# Altium schematic sheet Pressure Sensor Breakout Rev 2.SchDoc
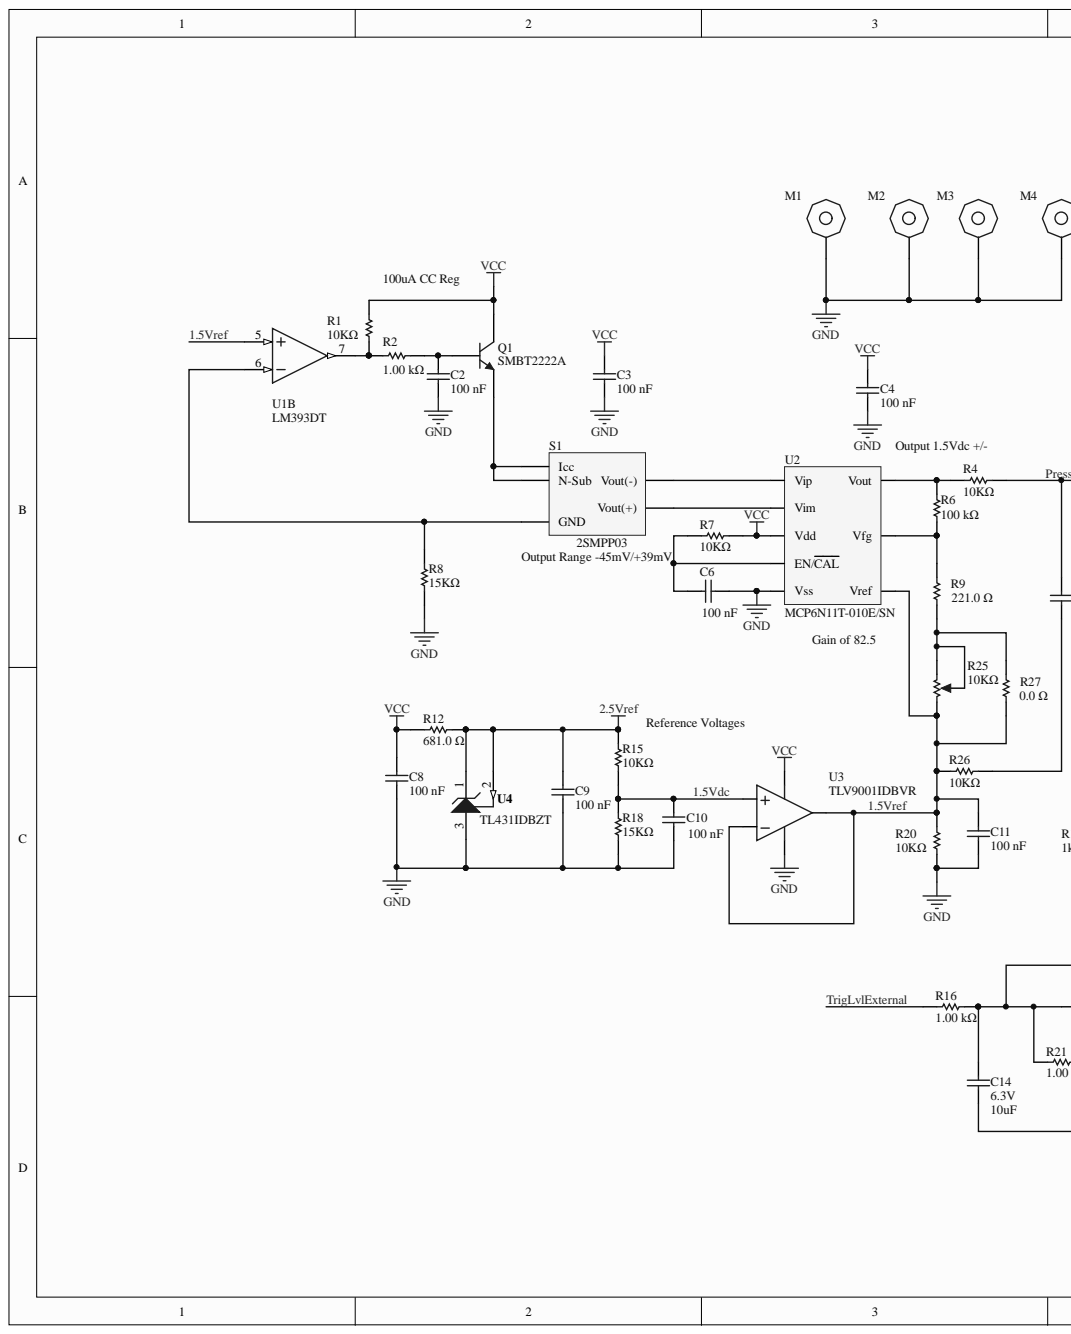
[diagram p0: Pressure Sensor Breakout Rev 2.SchDoc, left]
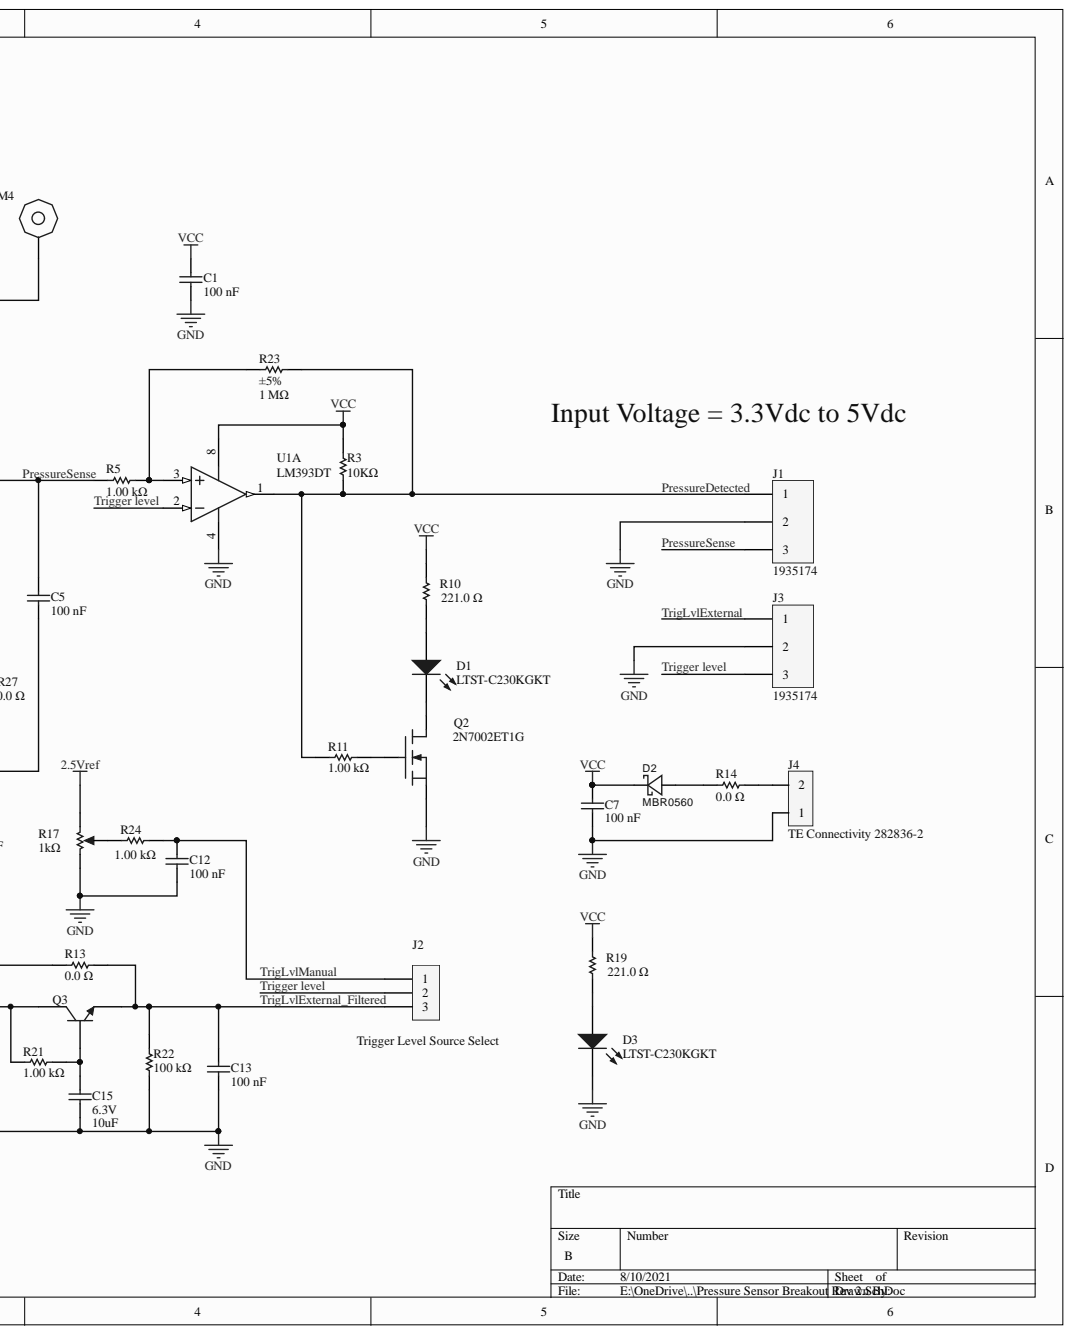
[diagram p1: Pressure Sensor Breakout Rev 2.SchDoc, right]

## Parts
C1  Capacitance: 100 nF  [Cap,0805]  (hidden comment: 08055C104JAT2A)
C2  08055C104JAT2A  [Cap,0805]  (value not drawn)
C3  Capacitance: 100 nF  [Cap,0805]  (hidden comment: 08055C104JAT2A)
C4  Capacitance: 100 nF  [Cap,0805]  (hidden comment: 08055C104JAT2A)
C5  Capacitance: 100 nF  [Cap,0805]  (hidden comment: 08055C104JAT2A)
C6  Capacitance: 100 nF  [Cap,0805]  (hidden comment: 08055C104JAT2A)
C7  Capacitance: 100 nF  [Cap,0805]  (hidden comment: 08055C104JAT2A)
C8  08055C104JAT2A  [Cap,0805]  (value not drawn)
C9  08055C104JAT2A  [Cap,0805]  (value not drawn)
C10  Capacitance: 100 nF  [Cap,0805]  (hidden comment: 08055C104JAT2A)
C11  Capacitance: 100 nF  [Cap,0805]  (hidden comment: 08055C104JAT2A)
C12  Capacitance: 100 nF  [Cap,0805]  (hidden comment: 08055C104JAT2A)
C13  Capacitance: 100 nF  [Cap,0805]  (hidden comment: 08055C104JAT2A)
C14  Capacitance: 10uF  Voltage - Rated: 6.3V  [Cap,0805]  (hidden comment: Cap)
C15  Capacitance: 10uF  Voltage - Rated: 6.3V  [Cap,0805]  (hidden comment: Cap)
D1  LTST-C230KGKT  [LED,SMD,Bottom Entry,1206]
D2  MBR0560  [SOD123]
D3  LTST-C230KGKT  [LED,SMD,Bottom Entry,1206]
J1  1935174  [CONNECTOR,TerminalBlock,3 POS,1935174]
J2  Generic Header  [Header,3POS,0.1",Vert]  (value not drawn)
J3  1935174  [CONNECTOR,TerminalBlock,3 POS,1935174]
J4  TE Connectivity 282836-2  [TERM BLOCK 2POS SIDE ENTRY 5MM]
M1  M3 hex Standoff  [Standoff,Hex,M3,8mm]  (value not drawn)
M2  M3 hex Standoff  [Standoff,Hex,M3,8mm]  (value not drawn)
M3  M3 hex Standoff  [Standoff,Hex,M3,8mm]  (value not drawn)
M4  M3 hex Standoff  [Standoff,Hex,M3,8mm]  (value not drawn)
Q1  SMBT2222A  [SOT23-3]
Q2  2N7002ET1G  [SOT23-3]
Q3  NPN  [SOT23-3]  (value not drawn)
R1  Resistance: 10KΩ  [res, 0805, HD]  (hidden comment: Vishay / Dale CRCW080510K0FKTA)
R2  Vishay CRCW08051K00JNEA  [res, 0805, HD]  (value not drawn)
R3  Resistance: 10KΩ  [res, 0805, HD]  (hidden comment: Vishay / Dale CRCW080510K0FKTA)
R4  Resistance: 10KΩ  [res, 0805, HD]  (hidden comment: Vishay / Dale CRCW080510K0FKTA)
R5  Resistance: 1.00 kΩ  [res, 0805, HD]  (hidden comment: Vishay CRCW08051K00JNEA)
R6  Resistance: 100 kΩ  [res, 0805, HD]  (hidden comment: CRCW0805100KFKEA)
R7  Resistance: 10KΩ  [res, 0805, HD]  (hidden comment: Vishay / Dale CRCW080510K0FKTA)
R8  Resistance: 15KΩ  [res, 0805, HD]  (hidden comment: CRGCQ0805F15K)
R9  Vishay Dale CRCW0805221RFKTA  [res, 0805, HD]  (value not drawn)
R10  Vishay Dale CRCW0805221RFKTA  [res, 0805, HD]  (value not drawn)
R11  Resistance: 1.00 kΩ  [res, 0805, HD]  (hidden comment: Vishay CRCW08051K00JNEA)
R12  Resistance: 681.0 Ω  [res, 0805, HD]  (hidden comment: CRCW0805681RFKEA)
R13  Resistance: 0.0 Ω  [res, 0805, HD]  (hidden comment: Res1)
R14  Resistance: 0.0 Ω  [res, 0805, HD]  (hidden comment: CR0805-J/-000ELF)
R15  Resistance: 10KΩ  [res, 0805, HD]  (hidden comment: Vishay / Dale CRCW080510K0FKTA)
R16  Resistance: 1.00 kΩ  [res, 0805, HD]  (hidden comment: Vishay CRCW08051K00JNEA)
R17  Resistance: 1kΩ  [Res,SMD,POT,TC33 Series]  (hidden comment: TC33X-2-102E)  (mechanical)
R18  Resistance: 15KΩ  [res, 0805, HD]  (hidden comment: CRGCQ0805F15K)
R19  Vishay Dale CRCW0805221RFKTA  [res, 0805, HD]  (value not drawn)
R20  Resistance: 10KΩ  [res, 0805, HD]  (hidden comment: Vishay / Dale CRCW080510K0FKTA)
R21  Resistance: 1.00 kΩ  [res, 0805, HD]  (hidden comment: Vishay CRCW08051K00JNEA)
R22  Resistance: 100 kΩ  [res, 0805, HD]  (hidden comment: Res,0805,100kΩ,1%,100ppm,CRCW0805100KFKEA)
R23  Resistance: 1 MΩ  Tolerance: ±5%  [res, 0805, HD]  (hidden comment: CR0805-JW-105ELF)
R24  Resistance: 1.00 kΩ  [res, 0805, HD]  (hidden comment: Vishay CRCW08051K00JNEA)
R25  Resistance: 10KΩ  [Res,TH,POT,TopAdj]  (hidden comment: CT94EW103)
R26  Resistance: 10KΩ  [res, 0805, HD]  (hidden comment: Vishay / Dale CRCW080510K0FKTA)
R27  Resistance: 0.0 Ω  [res, 0805, HD]  (hidden comment: CR0805-J/-000ELF)
S1  2SMPP03  [2SMPP03,6-SMD Module]
U1  LM393DT  [SOIC-8]  (parts A,B)
U2  MCP6N11T-010E/SN  [SOIC-8]
U3  TLV9001IDBVR  [SOT23-5]
U4  Manufacturer Part Number: TL431IDBZT  [SOT23-3]  (hidden comment: Shunt Regulator,2.5Vdc TL431IDBZT)

## Nets
2.5VREF: C9.1 R12.1 R15.2 R17.3 U4.1 U4.2
GND: C1.2 C2.2 C3.2 C4.2 C6.1 C7.2 C8.2 C9.2 C10.2 C11.2 C12.2 C13.2 C14.1 C15.1 D3.1 J1.2 J3.2 J4.1 M1.0 M2.0 M3.0 M4.0 Q2.2 R8.1 R17.1 R18.1 R20.1 R22.1 U1.4 U2.4(Vss) U3.2 U4.3
VCC: C1.1 C3.1 C4.1 C7.1 C8.1 D2.1 Q1.3 R1.2 R3.2 R7.2 R10.2 R12.2 R19.2 U1.8 U2.7(Vdd) U3.5
1.5VDC: C10.1 R15.1 R18.2 U3.3
1.5VREF: C11.1 R20.2 R25.1 R26.2 R27.1 U1.5 U2.5(Vref) U3.1 U3.4
PRESSUREDETECTED: J1.1 R3.1 R11.2 R23.1 U1.1
PRESSURESENSE: C5.1 J1.3 R4.1 R5.1
TRIGGER LEVEL: J2.2 J3.3 U1.2
TRIGLVLEXTERNAL: J3.1 R16.1
TRIGLVLEXTERNAL_FILTERED: C13.1 J2.3 Q3.3 R13.1 R22.2
TRIGLVLMANUAL: C12.1 J2.1 R24.1
NetC2_1: C2.1 Q1.1 R2.1
NetC5_2: C5.2 R26.1
NetC6_2: C6.2 R7.1 U2.8(EN/CAL)
NetC14_2: C14.2 Q3.1 R13.2 R16.2 R21.2
NetC15_2: C15.2 Q3.2 R21.1
NetD1_1: D1.1 Q2.3
NetD1_2: D1.2 R10.1
NetD2_2: D2.2 R14.2
NetD3_2: D3.2 R19.1
NetJ4_2: J4.2 R14.1
NetQ1_2: Q1.2 S1.5(N-Sub) S1.6(Icc)
NetQ2_1: Q2.1 R11.1
NetR1_1: R1.1 R2.2 U1.7
NetR4_2: R4.2 R6.2 U2.6(Vout)
NetR5_2: R5.2 R23.2 U1.3
NetR6_1: R6.1 R9.2 U2.1(Vfg)
NetR8_2: R8.2 S1.3(GND) U1.6
NetR9_1: R9.1 R25.2 R25.3 R27.2
NetR17_2: R17.2 R24.2
NetS1_1: S1.1(Vout(+)) U2.2(Vim)
NetS1_4: S1.4(Vout(-)) U2.3(Vip)

## Library data of the parts
C1 C3-C7 C10-C13 = Cap,0805,100nf,50V,5%,08055C104JAT2A: Capacitor; Ceramic; Cap 0.1 uF; Tol 5%; Vol-Rtg 50 VDC; SMT; 0805; X7R; Tape & Reel; Case/Package (SI): 2012; Detailed Description: 0.1µF ±5% 50V Ceramic Capacitor X7R 0805 (2012 Metric); Insulation Resistance: 100 GΩ; Lead-Free Status: Lead Free; Voltage Rating (DC): 50.0 V; Capacitance Tolerance: ±5%; Case/Package: 0805; Component Kind: Standard; Dielectric Characteristic: X7R; digikey url: http://www.digikey.ca/product-detail/en/avx-corporation/08055C104JAT2A/478-3352-1-ND/930144; Library Name: Master JULY2019.SchLib; Manufacturer Part Number: 08055C104JAT2A; Mounting Style: Surface Mount; Number of Pins: 2; Octopart URL: http://octopart.com/08055c104jat2a-avx-55388010; Operating Temperature: -55°C ~ 125°C; Packaging: Tape & Reel (TR), Cut Tape (CT); REACH SVHC Compliance: No SVHC; RoHS: Compliant; Size-Height: 710 µm; Size-Length: 2.01 mm; Size-Thickness: 940 µm; Size-Width: 1.24 mm; Supplier 1: Digi-Key; Supplier Part Number 1: 478-3352-1-ND
C2 C8 C9 = Cap,0805,100nf,50V,5%,08055C104JAT2A: Capacitor; Ceramic; Cap 0.1 uF; Tol 5%; Vol-Rtg 50 VDC; SMT; 0805; X7R; Tape & Reel; Capacitance: 100 nF; Case/Package (SI): 2012; Detailed Description: 0.1µF ±5% 50V Ceramic Capacitor X7R 0805 (2012 Metric); Insulation Resistance: 100 GΩ; Lead-Free Status: Lead Free; Voltage Rating (DC): 50.0 V; Capacitance Tolerance: ±5%; Case/Package: 0805; Component Kind: Standard; Dielectric Characteristic: X7R; digikey url: http://www.digikey.ca/product-detail/en/avx-corporation/08055C104JAT2A/478-3352-1-ND/930144; Library Name: Master JULY2019.SchLib; Manufacturer Part Number: 08055C104JAT2A; Mounting Style: Surface Mount; Number of Pins: 2; Octopart URL: http://octopart.com/08055c104jat2a-avx-55388010; Operating Temperature: -55°C ~ 125°C; Packaging: Tape & Reel (TR), Cut Tape (CT); REACH SVHC Compliance: No SVHC; RoHS: Compliant; Size-Height: 710 µm; Size-Length: 2.01 mm; Size-Thickness: 940 µm; Size-Width: 1.24 mm; Supplier 1: Digi-Key; Supplier Part Number 1: 478-3352-1-ND
C14 C15 = Cap,0805,10uf,6.3V,10%,CL21A106KQFNNNG: CL21 Series 10 uF 6.3V ±10 % Tolerance X5R SMT Multilayer Ceramic Capacitor; Lead-Free Status: Lead Free; Other Names: 1276-6457-2; Tolerance: ±10%; Applications: General Purpose; Component Kind: Standard; mfg P/N: CL21A106KQFNNNG; Mounting Style: Surface Mount; Operating Temperature: -55°C ~ 85°C; Package / Case: 0805 (2012 Metric); RoHS: Compliant; Library Name: Master JUL_2019-Shawn.IntLib
D1 D3 = LED,1206,Green,SMD,BtmEnt,LTST-C230KGKT: LED GREEN CLEAR CHIP SMD; Manufacturer: Lite-On Inc.; Categories: Optoelectronics   LED Indication - Discrete; Configuration: Standard; Current - Test: 20mA; Detailed Description: Green 571nm LED Indication - Discrete 2V 1206 (3216 Metric); Lens Color: Colorless; Lens Size: 1.50mm x 1.60mm; Lens Style: Rectangle with Flat Top; Millicandela Rating: 35mcd; Viewing Angle: 130°; Voltage - Forward (Vf) (Typ): 2V; Wavelength - Dominant: 571nm; Wavelength - Peak: 574nm; Color: Green; Component Kind: Standard; Height (Max): 1.10mm; Lens Transparency: Clear; mfg P/N: LTST-C230KGKT; Mounting Type: Surface Mount, Bottom Entry; Package / Case: 1206 (3216 Metric); Part Status: Active; Size / Dimension: 3.20mm L x 1.60mm W; Supplier 1: Digi-Key; Supplier Device Package: Chip LED; Supplier Part Number 1: 160-1456-1-ND; Library Name: Master DEC 2020.SchLib
D2 = Diode,SOD123,Shottky,60V,0.5A,MBR0560: Dio,Sig,Schtky,0.5A,60V,SOD123; Manufacturer: MCC; Details: 700mV @ 500mA, 200µA @ 60V,30pF @ 4V, 1MHz; mfg P/N: MBR0560-TP; Not Stuffed: N/S; Component Kind: Standard; Current: 0.5A; Fast Supplier: Digi-Key; Package: SOD123; Supplier 1: Digi-Key; Supplier 2: LCSC; Supplier Part Number 1: MBR0560TPMSCT-ND; Supplier Part Number 2: C150515; Voltage: 60V; Library Name: Master DEC 2020.SchLib
J1 J3 = Conn,TH,WireTerminal,3Pos,18A,300Vdc,1935174: TERM BLK 3POS SIDE ENTRY 5MM PCB; Manufacturer: Phoenix Contact; Current: 18A; Detailed Description: 3 Position Wire to Board Terminal Block Horizontal with Board 0.197" (5.00mm) Through Hole; Mating Orientation: Horizontal with Board; Number of Levels: 1; Other Names: 277-1578, PT 1.5/3-5.0-H, PT1.5/3-5.0-H; Pitch: 0.197"" (5.00mm); Positions Per Level: 3; Screw Thread: M2.6; Torque - Screw: 0.35-0.4 Nm (3.1-3.5 Lb-In); Voltage: 300V; Wire Gauge: 12-26 AWG; Wire Termination: Screw; Category: Connectors, Interconnects  Terminal Blocks - Wire to Board; Color: Green; Component Kind: Standard; Features: Interlocking (Side); Housing Material: Polyamide (PA), Nylon; mfg P/N: 1935174; Mounting Type: Through Hole; Package: Bulk; Part Status: Active; Series: COMBICON PT; Supplier 1: Digi-Key; Supplier Part Number 1: 277-1578-ND; Library Name: Master DEC 2020.SchLib
J2 = Header 1x3,0.1",TH,Generic: Generic Header 3 pos header 0.1"; Component Kind: Standard; Library Name: Master JULY2019.SchLib
J4 = TERM BLOCK 2POS SIDE ENTRY 5MM, 282836-2: Wire to Board 2 Position 5 mm Pitch 30-16 AWG Euro Style Terminal Block; Current Rating: 13.5 A; ELV Compliant: Compliant; Lead-Free Status: Lead Free; Number of Contacts: 2; Pin Pitch: 0.005 m; Voltage Rating (AC): 250.0 V; Wire Gauge: 15.0 AWG; Color: Green; Component Kind: Standard; Contact Material: Brass; Contact Plating: Tin; Flammability Rating: UL 94 V-0; Housing Color: Green; Housing Material: Nylon 6/6; Insulation Material: Nylon; Lifecycle Status: Active; Manufacturer Part Number: 282836-2; Mounting Style: PCB; Number of Positions: 2; Orientation: Right Angle; REACH SVHC Compliance: No SVHC; RoHS: Compliant; Supplier 1: TME; Supplier 2: Newark; Supplier 3: Digi-Key; Supplier 4: Digi-Key; Supplier Part Number 4: A98076-ND; Library Name: Master DEC 2020.SchLib
M1-M4 = Mech,Standoff, M3, Hex: HEX STANDOFF M3,generic; Component Kind: Standard; Library Name: Master JULY2019.SchLib; Supplier 1: Digi-Key; Supplier Part Number 1: 36-24314-ND
Q1 Q3 = Trans,40V,600mA,0.33W, SMBT2222A: NPN Silicon Switching Transistor, 40 V VCEO, 600 mA IC, SOT23, Reel, Green; Current - Collector Cutoff (Max): 10nA (ICBO); DC Current Gain (hFE) (Min) @ Ic, Vce: 100 @ 150mA, 10V; Frequency - Transition: 300MHz; Power Dissipation: 0.33 W; Vce Saturation (Max) @ Ib, Ic: 1V @ 50mA, 500mA; Voltage - Collector Emitter Breakdown (Max): 40V; Component Kind: Standard; mfg P/N: SMBT2222A; Mounting Style: Surface Mount; Mounting Type: Surface Mount; Number of Pins: 3; Package / Case: TO-236-3, SC-59, SOT-23-3; Packaging: Cut Tape (CT); Polarity: NPN; REACH SVHC Compliance: No SVHC; RoHS: Compliant; Supplier Device Package: SOT-23-3
  Q1: Library Name: Master JULY2019.SchLib; Supplier 1: Digi-Key; Supplier 2: Digi-Key; Supplier 3: Digi-Key; Supplier 4: LCSC; Supplier Part Number 1: SMBT2222AE6327HTSA1CT-ND; Supplier Part Number 2: MMBT2222ALT1HTSA1CT-ND; Supplier Part Number 3: SMBT2222AE6327HTSA1DKR-ND; Supplier Part Number 4: C151510
  Q3: Library Name: Master JUL_2019-Shawn.IntLib
Q2 = MOSFET,N-Channel,SOT23,0.25A,60V,2N7002ET1G: Small Signal MOSFET, 60 V, 310 mA, Single, N-Channel, 3-Pin SOT-23, Pb-Free, Tape and Reel; Manufacturer: ON Semiconductor; Continuous Drain Current (Ids): 0.25A; Drain to Source Voltage (Vds): 60.0 V; Lead-Free Status: Lead Free; Power Dissipation (Max): 350mW (Ta); Case/Package: SOT-23-3; Component Kind: Standard; Halogen Free Status: Halogen Free; Lifecycle Status: Active; Manufacturer Part Number: 2N7002ET1G; Number of Pins: 3; Polarity: N-Channel; REACH SVHC Compliance: No SVHC; RoHS: Compliant; Supplier 1: Digi-Key; Supplier 2: LCSC; Supplier Part Number 1: 2N7002ET1GOSCT-ND; Supplier Part Number 2: C71533; Library Name: Master DEC 2020.SchLib
R1 R3 R4 R7 R15 R20 R26 = Res,0805,10.0kΩ,1%,100 ppm,CRCW080510K0FKTA: RES SMD 10K OHM 1% 1/8W 0805; Case/Package (SI): 2012; Lead-Free Status: Contains Lead; Power Dissipation: 0.125 W; Temperature Coefficient: 100.0 ppm/C; Voltage Rating (DC): 150.0 V; Case/Package: 0805; Component Kind: Standard; Mounting Style: Surface Mount; Number of Pins: 2; Power Rating: 0.125 W; REACH SVHC Compliance: No SVHC; Resistance Tolerance: 1%; RoHS: Non-Compliant; Size-Height: 0.00045 m; Size-Length: 0.002 m; Size-Width: 0.00125 m; Supplier 1: Digi-Key; Supplier Part Number 1: 541-3016-1-ND
  R1 R3 R7 R15 R20: Library Name: Master JULY2019.SchLib
  R4 R26: Library Name: Master DEC 2020.SchLib
R2 = Res,0805,1.00 kΩ,5%,200ppm,CRCW08051K00JNEA: Res Thick Film 0805 1K Ohm 5% 0.125W(1/8W) ±200ppm/C Molded SMD Automotive Paper T/R; Case/Package (SI): 2012; Lead-Free Status: Lead Free; Power Rating: 125 mW; Resistance: 1.00 kΩ; Temperature Coefficient: 200 ppm/°C; Voltage Rating (DC): 150 V; Case/Package: 0805; Component Kind: Standard; Library Name: Master JULY2019.SchLib; Manufacturer Part Number: CRCW08051K00JNEA; Mounting Style: Surface Mount; Number of Pins: 2; Packaging: Tape & Reel (TR), Cut Tape (CT); REACH SVHC Compliance: No SVHC; Resistance Tolerance: ±5%; RoHS: Compliant; Size-Height: 450 µm; Size-Length: 2.00 mm; Size-Width: 1.25 mm; Supplier 1: Digi-Key; Supplier 2: Digi-Key; Supplier Part Number 1: 541-1.0KADKR-ND; Supplier Part Number 2: 541-1.0KACT-ND
R5 R11 R16 R21 R24 = Res,0805,1.00 kΩ,5%,200ppm,CRCW08051K00JNEA: Res Thick Film 0805 1K Ohm 5% 0.125W(1/8W) ±200ppm/C Molded SMD Automotive Paper T/R; Case/Package (SI): 2012; Lead-Free Status: Lead Free; Power Rating: 125 mW; Temperature Coefficient: 200 ppm/°C; Voltage Rating (DC): 150 V; Case/Package: 0805; Component Kind: Standard; Library Name: Master JULY2019.SchLib; Manufacturer Part Number: CRCW08051K00JNEA; Mounting Style: Surface Mount; Number of Pins: 2; Packaging: Tape & Reel (TR), Cut Tape (CT); REACH SVHC Compliance: No SVHC; Resistance Tolerance: ±5%; RoHS: Compliant; Size-Height: 450 µm; Size-Length: 2.00 mm; Size-Width: 1.25 mm
  R5 R11 R24: Supplier 1: Digi-Key; Supplier 2: Digi-Key; Supplier Part Number 1: 541-1.0KADKR-ND; Supplier Part Number 2: 541-1.0KACT-ND
R6 R22 = Res,0805,100kΩ,1%,100ppm,CRCW0805100KFKEA: 100 kOhms ±1% 0.125W, 1/8W Chip Resistor 0805 (2012 Metric) Thick Film; Manufacturer: Vishay; Case/Package (SI): 2012; Lead-Free Status: Lead Free; Power Rating: 125 mW; Temperature Coefficient: -100 ppm/°C to 100 ppm/°C; Case/Package: 0805; Component Kind: Standard; Manufacturer Part Number: CRCW0805100KFKEA; Number of Pins: 2; Operating Temperature: 150 °C (max); Packaging: Tape & Reel (TR), Reel, Cut Tape (CT); Resistance Tolerance: ±1%; RoHS: Compliant; Size-Height: 450 µm; Size-Length: 2.00 mm; Size-Width: 1.25 mm; Supplier 1: RS; Supplier 1 Part Number: 679-0803
  R6: Supplier 2: Digi-Key; Supplier Part Number 2: 541-100KCCT-ND; Library Name: Master DEC 2020.SchLib
  R22: Library Name: Master JULY2019.SchLib
R8 R18 = Res,0805,15KΩ,1%,±100ppm/°C,CRGCQ0805F15K: 15 kOhms ±1% 0.125W, 1/8W Chip Resistor 0805 (2012 Metric) Automotive AEC-Q200, Moisture Resistant Thick Film; Component Kind: Standard; Composition: Thick Film; Features: Automotive AEC-Q200, Moisture Resistant; Library Name: Master JULY2019.SchLib; mfg P/N: CRGCQ0805F15K; Operating Temperature: -55°C ~ 155°C; Package / Case: 0805 (2012 Metric); Power (Watts): 0.125W, 1/8W; Supplier 1: Digi-Key; Supplier Device Package: 0805; Supplier Part Number 1: A129763CT-ND; Temperature Coefficient: ±100ppm/°C; Tolerance: ±1%
R9 R10 R19 = Res,0805,221Ω,1%,100ppm,CRCW0805221RFKTA: RES SMD 221 OHM 1% 1/8W 0805; Case/Package (SI): 2012; Lead-Free Status: Contains Lead; Power Dissipation: 0.125 W; Resistance: 221.0 Ω; Temperature Coefficient: 100.0 ppm/°C; Voltage Rating (DC): 150.0 V; Case/Package: 0805; Component Kind: Standard; Mounting Style: Surface Mount; Number of Pins: 2; Power Rating: 0.125 W; Resistance Tolerance: ±1%; RoHS: Non-Compliant; Size-Height: 0.00045 m; Size-Length: 0.002 m; Size-Width: 0.00125 m; Supplier 1: Digi-Key; Supplier Part Number 1: 541-221CCT-ND; Library Name: Master DEC 2020.SchLib
R12 = Res,0805,681.0 Ω,±1%,100.0 ppm/°C,150.0 V,CRCW0805681RFKEA: RES SMD 681 OHM 1% 1/8W 0805; Case/Package (SI): 2012; Lead-Free Status: Lead Free; Power Rating: 0.125 W; Voltage Rating (DC): 150.0 V; Case/Package: 0805; Component Kind: Standard; Library Name: Master JULY2019.SchLib; mfg P/N: CRCW0805681RFKEA; Mounting Style: Surface Mount; Number of Pins: 2; Octopart Url: https://octopart.com/crcw0805681rfkea-vishay-39820193; REACH SVHC Compliance: No SVHC; Resistance Tolerance: ±1%; RoHS: Compliant; Size-Height: 0.00045 m; Size-Length: 0.002 m; Size-Width: 0.00125 m; Supplier 1: Digi-Key; Supplier Part Number 1: 541-681CCT-ND; Temperature Coefficient: 100.0 ppm/°C
R13 = Res,0805,0.0Ω,150V: RES SMD 0.0 OHM JUMPER 1/3W 0805; Lead-Free Status: Lead Free; Power Rating: 0.125 W; Voltage Rating (DC): 150.0 V; Case/Package: 0805; Component Kind: Standard; Library Name: Master JULY2019.SchLib; Number of Pins: 2; Octopart Url: https://octopart.com/crcw08050000z0eahp-vishay-44321084; RoHS: Compliant
R14 R27 = Res,0805,0.0Ω,150V, CR0805-J/-000ELF: RES SMD 0 OHM JUMPER 1/8W 0805; Categories: Resistors   Chip Resistor - Surface Mount; Detailed Description: 0 Ohms Jumper 0.125W, 1/8W Chip Resistor 0805 (2012 Metric) Moisture Resistant Thick Film; Lead-Free Status: Lead Free; Power Rating: 0.125 W; Voltage Rating (DC): 150.0 V; Base Part Number: CR0805; Case/Package: 0805; Component Kind: Standard; Composition: Thick Film; Number of Pins: 2; Operating Temperature: -55°C ~ 155°C; RoHS: Compliant; Supplier 1: Digi-Key; Supplier 2: Digi-Key; Supplier Part Number 1: CR0805-J/-000ELFCT-ND; Supplier Part Number 2: CR0805-J/-000ELFDKR-ND; Tolerance: Jumper; Library Name: Master DEC 2020.SchLib
R17 = Res,POT,SMD,1kΩ,0.1W, TC33X-2-102E: TRIMMER 1k OHM 0.1W J LEAD TOP; Manufacturer: Bourns Inc.; Adjustment Type: Top Adjustment; Detailed Description: 1k Ohms 0.1W, 1/10W J Lead Surface Mount Trimmer Potentiometer Cermet 1 Turn Top Adjustment; Number of Turns: 1; Power (Watts): 0.1W, 1/10W; Resistive Material: Cermet; Temperature Coefficient: ±250ppm/°C; Tolerance: ±25%; Category: Potentiometers, Variable Resistors  Trimmer Potentiometers; Datasheets: TC33 Series; Library Name: Master JULY2019.SchLib; mfg P/N: TC33X-2-102E; Mounting Type: Surface Mount; Part Status: Active; Series: Trimpot® TC33 - Open Frame; Size / Dimension: Rectangular - 0.150"" x 0.142"" Face x 0.047"" H (3.80mm x 3.60mm x 1.20mm); Supplier 1: Digi-Key; Supplier 2: Digi-Key; Supplier 3: Digi-Key; Supplier Part Number 3: TC33X-102ECT-ND; Termination Style: J Lead
R23 = Res,0805,1MegΩ,5%,200ppm,0805W8F1005T5E: RES SMD 1M OHM 5% 1/8W 0805; Manufacturer: Bourns Inc.; Detailed Description: 1 MOhms ±5% 0.125W, 1/8W Chip Resistor 0805 (2012 Metric) Moisture Resistant Thick Film; Power (Watts): 0.125W, 1/8W; Temperature Coefficient: ±200ppm/°C; Category: Resistors  Chip Resistor - Surface Mount; Component Kind: Standard; Composition: Thick Film; Features: Moisture Resistant; Height - Seated (Max): 0.024"" (0.60mm); JLC ALt LCSC Part #: C26108; Library Name: Master JULY2019.SchLib; mfg P/N: CR0805-JW-105ELF; Operating Temperature: -55°C ~ 155°C; Package / Case: 0805 (2012 Metric); Part Status: Active; Series: CR0805; Size / Dimension: 0.079"" L x 0.049"" W (2.00mm x 1.25mm); Supplier 1: Digi-Key; Supplier Device Package: 0805; Supplier Part Number 1: CR0805-JW-105ELFCT-ND
R25 = Res,POT,TH,10KΩ,0.5W,±10%,±100ppm/°C,CT94EW103: TRIMMER 10K OHM 0.5W PC PIN TOP; Manufacturer: Nidec Copal Electronics; Adjustment Type: Top Adjustment; Detailed Description: 10 kOhms 0.5W, 1/2W PC Pins Through Hole Trimmer Potentiometer Cermet 18 Turn Top Adjustment; Number of Turns: 18; Power (Watts): 0.5W, 1/2W; Resistive Material: Cermet; Tolerance: ±10%; Category: Potentiometers, Variable Resistors; Component Kind: Standard; Library Name: Master DEC 2020.SchLib; mfg P/N: CT94EW103; Mounting Type: Through Hole; Part Status: Active; Series: CT94; Size / Dimension: Square - 0.378"" L x 0.189"" W x 0.378"" H (9.60mm x 4.80mm x 9.60mm); Supplier 1: Digi-Key; Supplier Part Number 1: CT94EW103-ND; Temperature Coefficient: ±100ppm/°C; Termination Style: PC Pins
S1 = Sensor,PRESSURE,Air, ±7.25PSI, 2SMPP03: SENSOR PRESSURE -50-50 KPA PCB; Manufacturer: Omron Electronics Inc-EMC Div; Accuracy: ±0.5%; Detailed Description: Pressure Sensor ±7.25PSI (±50kPa) Compound Male - 0.1" (2.59mm) Tube -43 mV ~ 42 mV 6-SMD Module; Maximum Pressure: -11.6PSI ~ 17.4PSI (-80kPa ~ 120kPa); Operating Pressure: ±7.25PSI (±50kPa); Output: -43 mV ~ 42 mV; Output Type: Analog Voltage; Port Size: Male - 0.1"" (2.59mm) Tube; Port Style: Barbless; Pressure Type: Compound; Applications: Board Mount; Category: Sensors, Transducers  Pressure Sensors, Transducers; Component Kind: Standard; Library Name: Master JULY2019.SchLib; mfg P/N: 2SMPP03; Operating Temperature: -10°C ~ 100°C; Package / Case: 6-SMD Module; Part Status: Active; Series: 2SMPP; Supplier 1: Digi-Key; Supplier Part Number 1: Z3639-ND; Termination Style: Gull Wing
U1 = OPAMP,DUAL,COMAPRATOR,SOIC8,OPEN-COLLECTOR,LM393DT: IC COMPARATOR LP DUAL 8-SOIC; Manufacturer: STMicroelectronics; Current - Input Bias (Max): 0.25µA @ 5V; Current - Output (Typ): 18mA @ 5V; Current - Quiescent (Max): 2.5mA; Number of Elements: 2; Output Type: CMOS, DTL, ECL, MOS, Open-Collector, TTL; Package / Case 8-SOIC: (0.154", 3.90mm Width); Voltage - Input Offset (Max): 5mV @ 30V; Voltage - Supply, Single/Dual (±): 2V ~ 36V, ±1V ~ 18V; Base Part Number: LM393; Component Kind: Standard; Library Name: Master JULY2019.SchLib; mfg P/N: LM393DT; Mounting Type: Surface Mount; Operating Temperature: 0°C ~ 70°C; Part Status: Active; Supplier 1: Digi-Key; Supplier 2: LCSC; Supplier Device Package: 8-SO; Supplier Part Number 1: 497-1593-1-ND; Supplier Part Number 2: C32901; Type: General Purpose
U2 = Opamp,Instrumentation,SOIC,5Mhz,RailToRail, MCP6N11T-010E/SN: IC INST AMP 1 CIRCUIT 8SOIC; Manufacturer: Microchip Technology; Current - Input Bias: 10pA; Current - Supply: 800µA; Detailed Description: Instrumentation Amplifier 1 Circuit Rail-to-Rail 8-SOIC; Gain Bandwidth Product: 5MHz; Number of Circuits: 1; Output Type: Rail-to-Rail; Slew Rate: 9V/µs; Voltage - Input Offset: 500µV; Voltage - Supply, Single/Dual (±): 1.8V ~ 5.5V; Amplifier Type: Instrumentation; Base Product Number: MCP6N11; Category: Integrated Circuits (ICs)  Linear - Amplifiers - Instrumentation, OP Amps, Buffer Amps; Component Kind: Standard; Library Name: Master JULY2019.SchLib; mfg P/N: MCP6N11T-010E/SN; Mounting Type: Surface Mount; Operating Temperature: -40°C ~ 125°C; Package / Case: 8-SOIC (0.154"", 3.90mm Width); Part Status: Active; Supplier 1: Digi-Key; Supplier Device Package: 8-SOIC; Supplier Part Number 1: MCP6N11T-010E/SNCT-ND
U3 = OPAMP,Single,1Mhz,RailToRail,SOT-5, TLV9001IDBVR: IC CMOS 1 CIRCUIT SOT23-5; Manufacturer: Texas Instruments; Base Product Number: TLV900; Current - Input Bias: 5pA; Current - Output / Channel: 40mA; Current - Supply: 60µA; Detailed Description: CMOS Amplifier 1 Circuit Rail-to-Rail SOT-23-5; Gain Bandwidth Product: 1MHz; Number of Circuits: 1; Output Type: Rail-to-Rail; Slew Rate: 2V/µs; Voltage - Input Offset: 400µV; Voltage - Supply, Single/Dual (±): 1.8V ~ 5.5V, ±0.9V ~ 2.75V; Amplifier Type: CMOS; Category: Integrated Circuits (ICs)  Linear - Amplifiers - Instrumentation, OP Amps, Buffer Amps; Component Kind: Standard; Library Name: Master JULY2019.SchLib; mfg P/N: TLV9001IDBVR; Mounting Type: Surface Mount; Operating Temperature: -40°C ~ 125°C; Package / Case: SC-74A, SOT-753; Part Status: Active; Supplier 1: Digi-Key; Supplier 2: LCSC; Supplier 3: Digi-Key; Supplier Device Package: SOT-23-5; Supplier Part Number 1: 296-TLV9001IDBVRCT-ND; Supplier Part Number 2: C398363; Supplier Part Number 3: TLV9001IDBVR-ND
U4 = Shunt Regulator,2.5Vdc TL431IDBZT: Adjustable Precision Shunt Regulator, 34 ppm / degC, 100 mA, -40 to 85 degC, 3-pin SOT-23 (DBZ), Green (RoHS & no Sb/Br); Lead-Free Status: Lead Free; Output Current: 0.1 A; Temperature Coefficient: 34.0 ppm/°C; Case/Package: SOT-23; Component Kind: Standard; Library Name: Master JULY2019.SchLib; Lifecycle Status: Active; Number of Pins: 3; OctopartID: acb465b256687373; Octopart Url: https://octopart.com/tl431idbzt-texas+instruments-843706; Packaging: Cut Tape (CT); RoHS: Compliant; Supplier 1: Digi-Key; Supplier Part Number 1: 296-26719-1-ND
